# Altium schematic sheet 10_Misc.SchDoc(10_Misc)
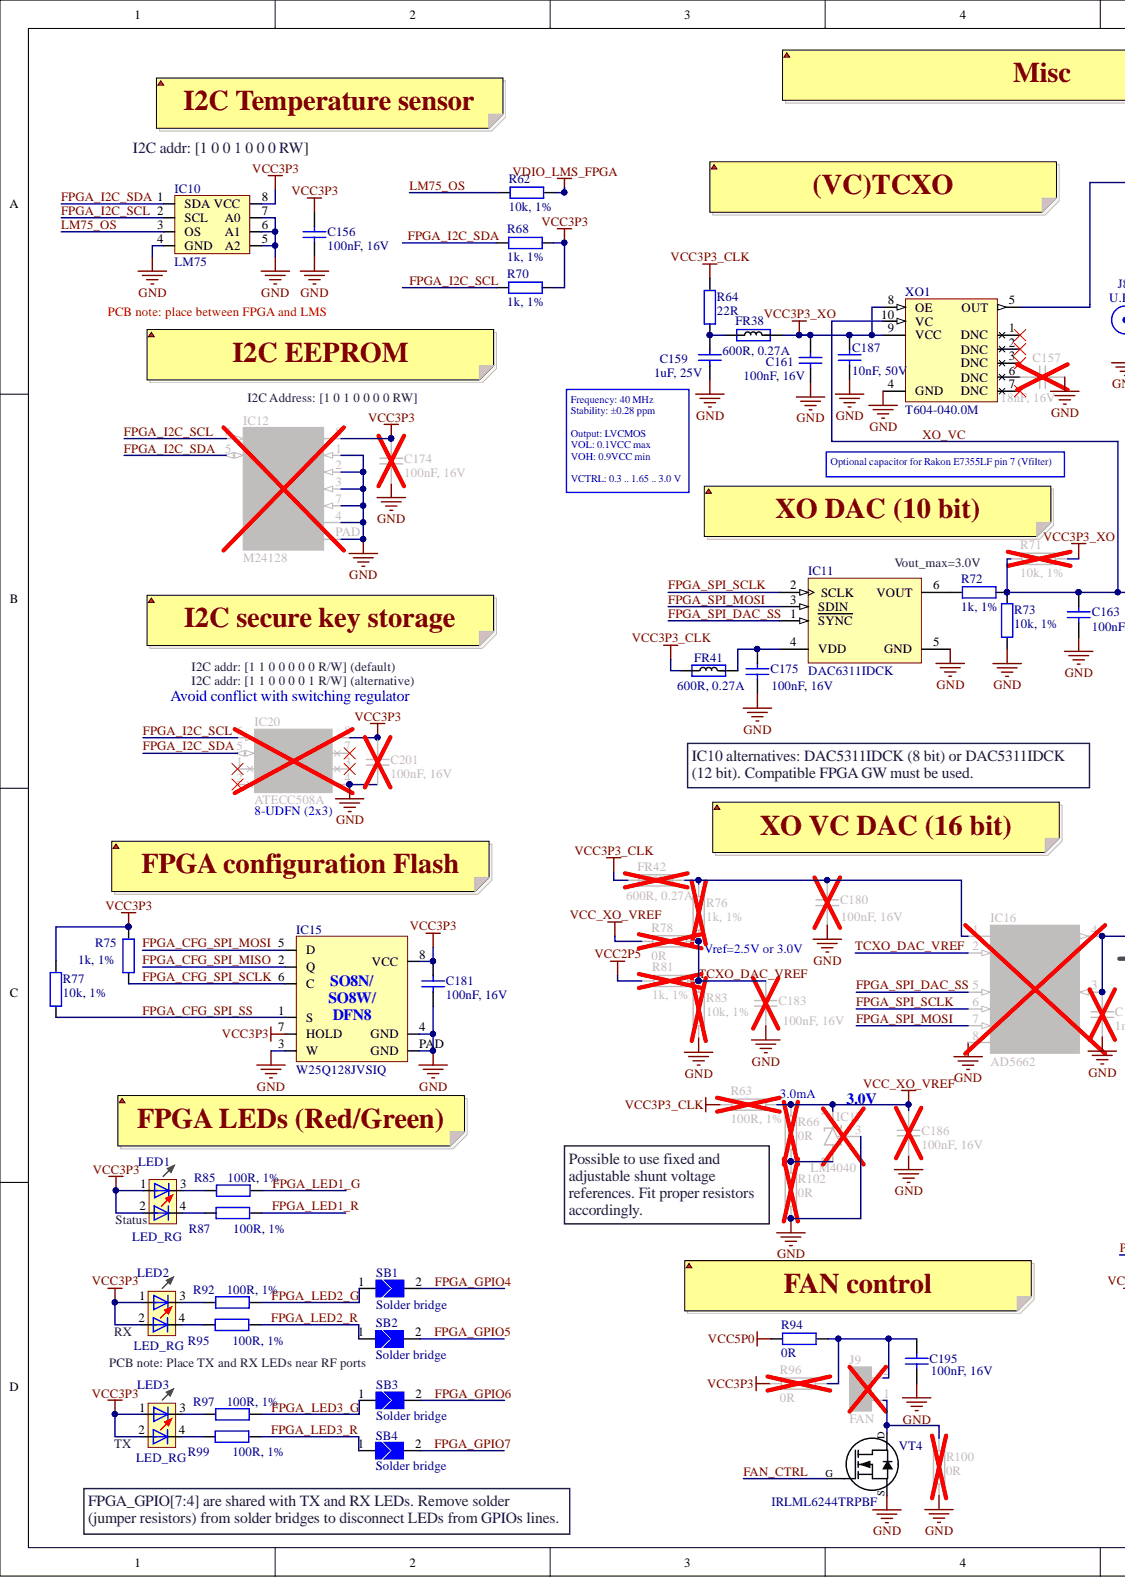
[diagram p0: 10_Misc.SchDoc, left]
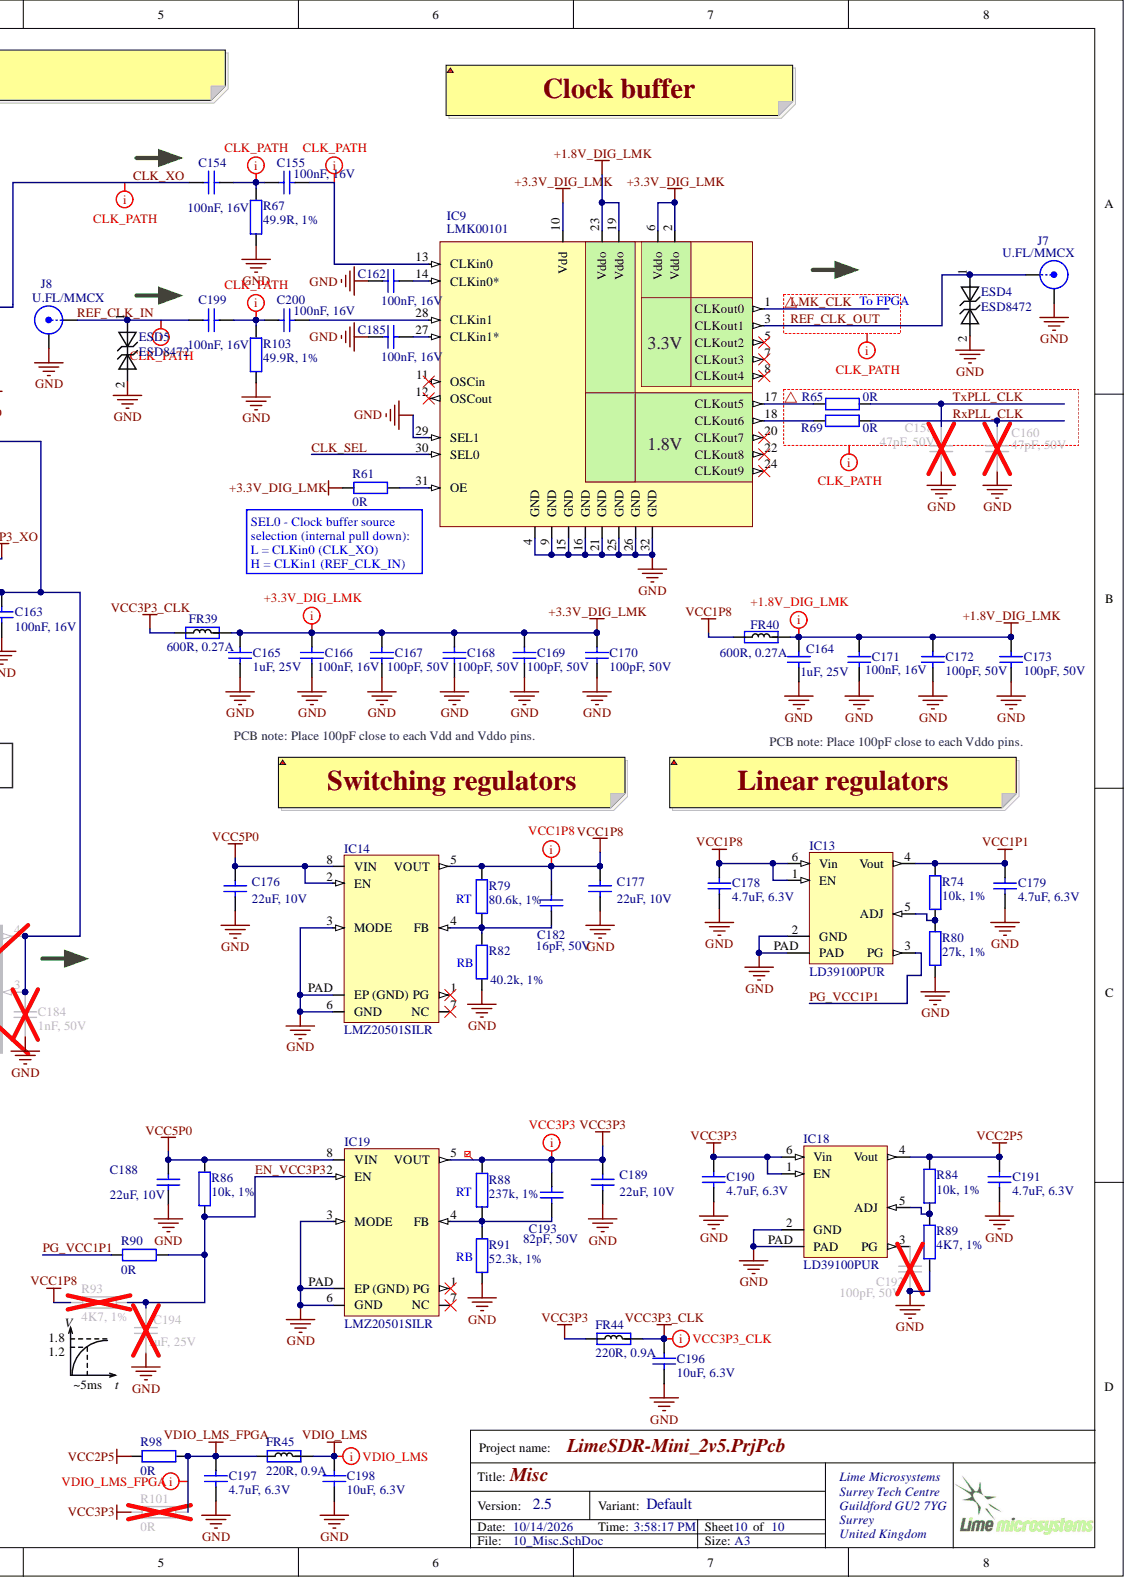
[diagram p1: 10_Misc.SchDoc, right]

## Parts
C154  100nF, 16V  [CAP0402]
C155  100nF, 16V  [CAP0402]
C156  100nF, 16V  [CAP0402]
C157  18nF, 16V  Voltage - Rated: 16V  [CAP0402]
C158  47pF, 50V  Voltage - Rated: 50V  [CAP0402]
C159  1uF, 25V  [CAP0402]
C160  47pF, 50V  [CAP0402]
C161  100nF, 16V  [CAP0402]
C162  100nF, 16V  [CAP0402]
C163  100nF, 16V  [CAP0402]
C164  1uF, 25V  [CAP0402]
C165  1uF, 25V  [CAP0402]
C166  100nF, 16V  [CAP0402]
C167  100pF, 50V  [CAP0402]
C168  100pF, 50V  Voltage - Rated: 50V  [CAP0402]
C169  100pF, 50V  Voltage - Rated: 50V  [CAP0402]
C170  100pF, 50V  Voltage - Rated: 50V  [CAP0402]
C171  100nF, 16V  [CAP0402]
C172  100pF, 50V  [CAP0402]
C173  100pF, 50V  Voltage - Rated: 50V  [CAP0402]
C174  100nF, 16V  [CAP0402]
C175  100nF, 16V  [CAP0402]
C176  22uF, 10V  [CAP0603]
C177  22uF, 10V  [CAP0603]
C178  4.7uF, 6.3V  [CAP0402]
C179  4.7uF, 6.3V  [CAP0402]
C180  100nF, 16V  [CAP0402]
C181  100nF, 16V  [CAP0402]
C182  16pF, 50V  Voltage Rating (DC): 50V  [CAP0402]
C183  100nF, 16V  [CAP0402]
C184  1nF, 50V  [CAP0402]
C185  100nF, 16V  [CAP0402]
C186  100nF, 16V  [CAP0402]
C187  10nF, 50V  [CAP0402]
C188  22uF, 10V  [CAP0603]
C189  22uF, 10V  [CAP0603]
C190  4.7uF, 6.3V  [CAP0402]
C191  4.7uF, 6.3V  [CAP0402]
C192  100pF, 50V  Voltage - Rated: 50V  [CAP0402]
C193  82pF, 50V  [CAP0402]
C194  1uF, 25V  [CAP0402]
C195  100nF, 16V  [CAP0402]
C196  10uF, 6.3V  Voltage - Rated: 6.3V  [CAP0603]
C197  4.7uF, 6.3V  [CAP0402]
C198  10uF, 6.3V  Voltage - Rated: 6.3V  [CAP0603]
C199  100nF, 16V  [CAP0402]
C200  100nF, 16V  [CAP0402]
C201  100nF, 16V  [CAP0402]
ESD4  ESD8472  [ESD8472_X3DFN2]
ESD5  ESD8472  [ESD8472_X3DFN2]
FR38  600R, 0.27A  [RES0201]
FR39  600R, 0.27A  [RES0201]
FR40  600R, 0.27A  [RES0201]
FR41  600R, 0.27A  [RES0201]
FR42  600R, 0.27A  [RES0201]
FR44  220R, 0.9A  [RES0402]
FR45  220R, 0.9A  [RES0402]
IC9  LMK00101  [LMK00101_WQFN32_r1]
IC10  LM75  [HWSON8_LM75]
IC11  DAC6311IDCK  [AD5601_SOT23-6]
IC12  M24128  [M24128-DFMC6TG]
IC13  LD39100PUR  [LD39100PUR]
IC14  LMZ20501SILR  [LMZ20501SILR_DC-DC_8-SMD]
IC15  W25Q128JVSIQ  [S25FL164K_WSON8_DFN6]
IC16  AD5662  [AD5662_SOT23-8]
IC17  LM4040  [SOT23-3_V3]
IC18  LD39100PUR  [LD39100PUR]
IC19  LMZ20501SILR  [LMZ20501SILR_DC-DC_8-SMD]
IC20  ATECC508A  [ATECC508A_UDFN8_v2]
J7  U.FL/MMCX  [MMCX_UFL_conn_u]
J8  U.FL/MMCX  [MMCX_UFL_conn_u]
J9  FAN  [Header2x1_TH_v2]
LED1  LED_RG  [LED-2x0603]
LED2  LED_RG  [LED-2x0603]
LED3  LED_RG  [LED-2x0603]
R61  0R  [RES0402]
R62  10k, 1%  [RES0402]
R63  100R, 1%  [RES0402]
R64  22R  [RES0402]
R65  0R  [RES0402]
R66  0R  [RES0402]
R67  49.9R, 1%  [RES0402]
R68  1k, 1%  [RES0402]
R69  0R  [RES0402]
R70  1k, 1%  [RES0402]
R71  10k, 1%  [RES0402]
R72  1k, 1%  [RES0402]
R73  10k, 1%  [RES0402]
R74  10k, 1%  [RES0402]
R75  1k, 1%  [RES0402]
R76  1k, 1%  [RES0402]
R77  10k, 1%  [RES0402]
R78  0R  [RES0402]
R79  80.6k, 1%  [RES0402]
R80  27k, 1%  Tolerance: 1%  [RES0402]
R81  1k, 1%  [RES0402]
R82  40.2k, 1%  [RES0402]
R83  10k, 1%  [RES0402]
R84  10k, 1%  [RES0402]
R85  100R, 1%  [RES0402]
R86  10k, 1%  [RES0402]
R87  100R, 1%  [RES0402]
R88  237k, 1%  [RES0402]
R89  4K7, 1%  [RES0402]
R90  0R  [RES0402]
R91  52.3k, 1%  [RES0402]
R92  100R, 1%  [RES0402]
R93  4K7, 1%  [RES0402]
R94  0R  [RES0402]
R95  100R, 1%  [RES0402]
R96  0R  [RES0402]
R97  100R, 1%  [RES0402]
R98  0R  [RES0402]
R99  100R, 1%  [RES0402]
R100  0R  [RES0402]
R101  0R  [RES0402]
R102  0R  [RES0402]
R103  49.9R, 1%  [RES0402]
SB1  Solder bridge  [SOLDER_BRIDGE_TRIANGULAR_v2]
SB2  Solder bridge  [SOLDER_BRIDGE_TRIANGULAR_v2]
SB3  Solder bridge  [SOLDER_BRIDGE_TRIANGULAR_v2]
SB4  Solder bridge  [SOLDER_BRIDGE_TRIANGULAR_v2]
VT4  IRLML6244TRPBF  [SOT23-3]
XO1  T604-040.0M  [T604-040.0M_VCTCXO_ConnorWinfield]

## Nets
+1.8V_DIG_LMK: C164.2 C171.2 C172.2 C173.2 FR40.2 IC9.19(Vddo) IC9.23(Vddo)
+3.3V_DIG_LMK: C165.2 C166.2 C167.2 C168.2 C169.2 C170.2 FR39.2 IC9.2(Vddo) IC9.6(Vddo) IC9.10(Vdd) R61.1
GND: C156.2 C157.1 C158.1 C159.1 C160.1 C161.1 C162.2 C163.1 C164.1 C165.1 C166.1 C167.1 C168.1 C169.1 C170.1 C171.1 C172.1 C173.1 C174.2 C175.2 C176.1 C177.1 C178.1 C179.1 C180.2 C181.2 C183.2 C184.2 C185.2 C186.1 C187.1 C188.1 C189.1 C190.1 C191.1 C192.1 C194.1 C195.2 C196.1 C197.1 C198.1 C201.2 ESD4.2 ESD5.2 IC9.4(GND) IC9.9(GND) IC9.15(GND) IC9.16(GND) IC9.21(GND) IC9.25(GND) IC9.26(GND) IC9.29(SEL1) IC9.32(GND) IC10.4(GND) IC10.5(A2) IC10.6(A1) IC10.7(A0) IC11.5(GND) IC12.1(E0) IC12.2(E1) IC12.3(E2) IC12.4(VSS) IC12.7(WC) IC12.PAD IC13.2(GND) IC13.PAD IC14.3(MODE) IC14.6(GND) IC14.PAD(EP_(GND)) IC15.3(W) IC15.4(GND) IC15.PAD(GND) IC16.8(GND) IC17.3 IC18.2(GND) IC18.PAD IC19.3(MODE) IC19.6(GND) IC19.PAD(EP_(GND)) IC20.4(GND) J7.2 J8.2 R67.1 R73.2 R80.2 R82.1 R83.2 R89.2 R91.1 R100.1 R102.2 R103.1 VT4.S XO1.4(GND)
VCC1P1: C179.2 IC13.4(Vout) R74.2
VCC1P8: C177.2 C178.2 C182.1 FR40.1 IC13.1(EN) IC13.6(Vin) IC14.5(VOUT) R79.2 R93.2
VCC2P5: C191.2 IC18.4(Vout) R81.2 R84.2 R98.1
VCC3P3: C156.1 C174.1 C181.1 C189.2 C190.2 C193.1 C201.1 FR44.1 IC10.8(VCC) IC12.8(VCC) IC15.7(HOLD) IC15.8(VCC) IC18.1(EN) IC18.6(Vin) IC19.5(VOUT) IC20.8(VCC) LED1.1 LED1.2 LED2.1 LED2.2 LED3.1 LED3.2 R68.1 R70.1 R75.2 R77.2 R88.2 R96.1 R101.1
VCC3P3_CLK: C196.2 FR39.1 FR41.1 FR42.1 FR44.2 R63.2 R64.2
VCC3P3_XO: C161.2 C187.2 FR38.2 R71.2 XO1.8(OE) XO1.9(VCC)
VCC5P0: C176.2 C188.2 IC14.2(EN) IC14.8(VIN) IC19.8(VIN) R86.2 R94.1
VCC_XO_VREF: C186.2 IC17.1 R63.1 R66.1 R78.1
VDIO_LMS: C198.2 FR45.2
VDIO_LMS_FPGA: C197.2 FR45.1 R62.2 R98.2 R101.2
CLK_SEL: IC9.30(SEL0)
CLK_XO: C154.2 XO1.5(OUT)
EN_VCC3P3: C194.2 IC19.2(EN) R86.1 R90.2 R93.1
FAN_CTRL: VT4.G
FPGA_CFG_SPI_MISO: IC15.2(Q)
FPGA_CFG_SPI_MOSI: IC15.5(D)
FPGA_CFG_SPI_SCLK: IC15.6(C) R75.1
FPGA_CFG_SPI_SS: IC15.1(S) R77.1
FPGA_GPIO4: SB1.2
FPGA_GPIO5: SB2.2
FPGA_GPIO6: SB3.2
FPGA_GPIO7: SB4.2
FPGA_I2C_SCL: IC10.2(SCL) IC12.6(SCL) IC20.6(SCL) R70.2
FPGA_I2C_SDA: IC10.1(SDA) IC12.5(SDA) IC20.5(SDA) R68.2
FPGA_LED1_G: R85.2
FPGA_LED1_R: R87.2
FPGA_LED2_G: R92.2 SB1.1
FPGA_LED2_R: R95.2 SB2.1
FPGA_LED3_G: R97.2 SB3.1
FPGA_LED3_R: R99.2 SB4.1
FPGA_SPI_DAC_SS: IC11.1(SYNC) IC16.5(SYNC)
FPGA_SPI_MOSI: IC11.3(SDIN) IC16.7(DIN)
FPGA_SPI_SCLK: IC11.2(SCLK) IC16.6(SCLK)
LM75_OS: IC10.3(OS) R62.1
LMK_CLK: IC9.1(CLKout0)
PG_VCC1P1: IC13.3(PG) R90.1
REF_CLK_IN: C199.2 ESD5.1 J8.1
REF_CLK_OUT: ESD4.1 IC9.3(CLKout1) J7.1
RxPLL_CLK: C160.2 R69.1
TCXO_DAC_VREF: C183.1 IC16.2(Vref) R76.1 R78.2 R81.1 R83.1
TxPLL_CLK: C158.2 R65.1
XO_VC: C163.2 C184.1 IC16.3(Vfb) IC16.4(Vout) R71.1 R72.1 R73.1 XO1.10(VC)
NetC154_1: C154.1 C155.2 R67.2
NetC155_1: C155.1 IC9.13(CLKin0)
NetC157_2: C157.2 XO1.6(DNC)
NetC159_2: C159.2 FR38.1 R64.1
NetC162_1: C162.1 IC9.14(CLKin0*)
NetC175_1: C175.1 FR41.2 IC11.4(VDD)
NetC180_1: C180.1 FR42.2 IC16.1(Vdd) R76.2
NetC182_2: C182.2 IC14.4(FB) R79.1 R82.2
NetC185_1: C185.1 IC9.27(CLKin1*)
NetC192_2: C192.2 IC18.3(PG)
NetC193_2: C193.2 IC19.4(FB) R88.1 R91.2
NetC195_1: C195.1 J9.2 R94.2 R96.2
NetC199_1: C199.1 C200.2 R103.2
NetC200_1: C200.1 IC9.28(CLKin1)
NetIC9_17: IC9.17(CLKout5) R65.2
NetIC9_18: IC9.18(CLKout6) R69.2
NetIC9_31: IC9.31(OE) R61.2
NetIC11_6: IC11.6(VOUT) R72.2
NetIC13_5: IC13.5(ADJ) R74.1 R80.1
NetIC17_2: IC17.2 R66.2 R102.1
NetIC18_5: IC18.5(ADJ) R84.1 R89.1
NetJ9_1: J9.1 R100.2 VT4.D
NetLED1_3: LED1.3 R85.1
NetLED1_4: LED1.4 R87.1
NetLED2_3: LED2.3 R92.1
NetLED2_4: LED2.4 R95.1
NetLED3_3: LED3.3 R97.1
NetLED3_4: LED3.4 R99.1
N00498:
N00499:

Not connected: IC9 5(CLKout2) 7(CLKout3) 8(CLKout4) 11(OSCin) 12(OSCout) 20(CLKout7) 22(CLKout8) 24(CLKout9); IC14 1 7(NC); IC19 1 7(NC); IC20 1(NC) 2(NC) 3(NC) 7(NC); XO1 1(DNC) 2(DNC) 3(DNC) 7(DNC)
